# Altium schematic sheet AUAV_X05_IMU.SchDoc(AUAV_X05_IMU)
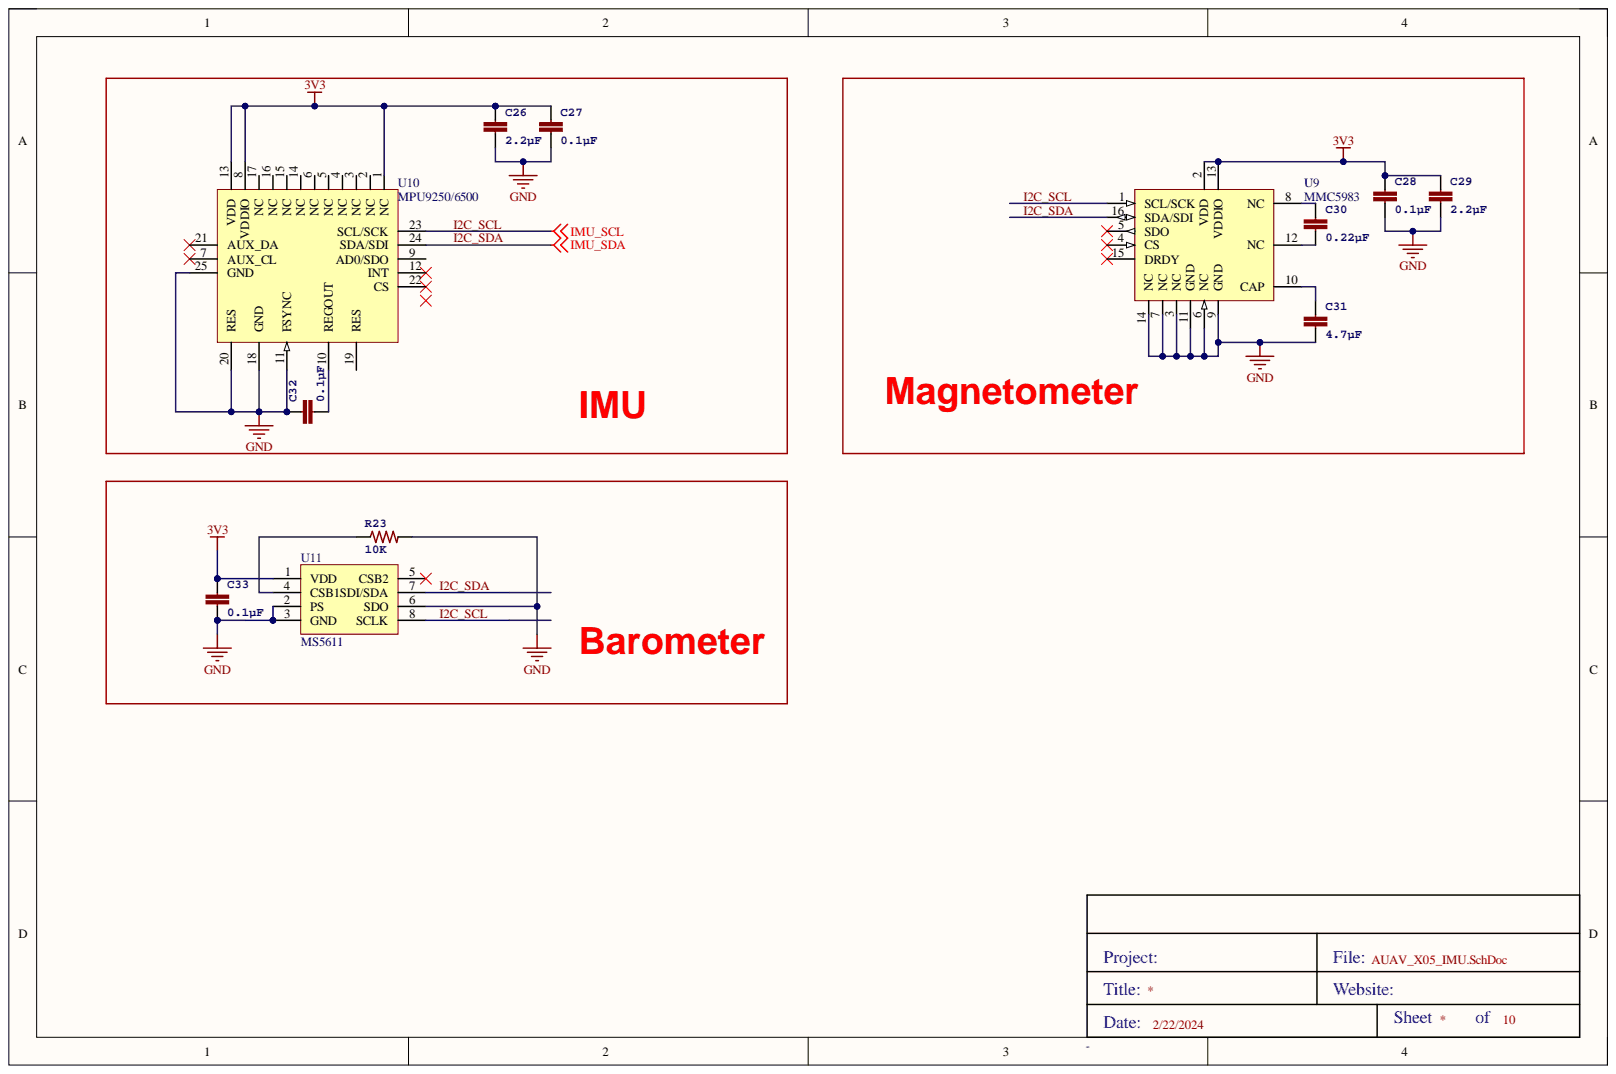
[diagram p0: AUAV_X05_IMU.SchDoc]

## Parts
*?  Title Block  (value not drawn)
C26  2.2µF  [0402-CAP]
C27  0.1µF  [0402-CAP]
C28  0.1µF  [0402-CAP]
C29  2.2µF  [0402-CAP]
C30  0.22µF  [0402-CAP]
C31  4.7µF  [0603-CAP]
C32  0.1µF  [0402-CAP]
C33  0.1µF  [0402-CAP]
R23  10K  [0402-RES]
U9  MMC5983  [MMC5983]
U10  MPU9250/6500  [QFN24_3X3X1_IVS]
U11  MS5611  [MS5611-01BA]

## Nets
3V3: C26.P$1 C27.P$1 C28.P$1 C29.P$1 C33.P$1 U9.2(VDD) U9.13(VDDIO) U10.1(NC) U10.8(VDDIO) U10.13(VDD) U11.1(VDD)
GND: C26.P$2 C27.P$2 C28.P$2 C29.P$2 C31.P$2 C32.P$1 C33.P$2 R23.P$2 U9.3(NC) U9.6(NC) U9.7(NC) U9.9(GND) U9.11(GND) U9.14(NC) U10.11(FSYNC) U10.18(GND) U10.20(RES) U10.25(GND) U11.2(PS) U11.3(GND) U11.6(SDO)
IMU_SCL: U9.1(SCL/SCK) U10.23(SCL/SCK) U11.8(SCLK)
IMU_SDA: U9.16(SDA/SDI) U10.24(SDA/SDI) U11.7(SDI/SDA)
NetC30_P$1: C30.P$1 U9.8(NC)
NetC30_P$2: C30.P$2 U9.12(NC)
NetC31_P$1: C31.P$1 U9.10(CAP)
NetC32_P$2: C32.P$2 U10.10(REGOUT)
NetR23_P$1: R23.P$1 U11.4(CSB1)

Not connected: U9 4(CS) 5(SDO) 15(DRDY); U10 2(NC) 3(NC) 4(NC) 5(NC) 6(NC) 7(AUX_CL) 9(AD0/SDO) 12(INT) 14(NC) 15(NC) 16(NC) 17(NC) 19(RES) 21(AUX_DA) 22(CS); U11 5(CSB2)

## Library data of the parts
C26-C30 C32 C33 = CAP0402: CAP CER 8PF 50V C0G 0402; 3DR_PARTNO: ECM0710; PARTNO: C1005C0G1H080C; Value: 8p; Component Kind: Standard; Supplier 1: Digi-Key; Supplier Part Number 1: 445-4890-1-ND; Library Name: AUAV_X05.SchLib
C31 = CAP0603: CAP CER 2.2UF 16V 10% X6S 0603; 3DR_PARTNO: ECM0619; PARTNO: C1608X6S1C225K080AC; Value: 2u2; Component Kind: Standard; Supplier 1: Digi-Key; Supplier Part Number 1: 445-7438-1-ND; Library Name: AUAV_X05.SchLib
R23 = RESISTOR0402-RES: RES 10K OHM 1/16W 1% 0402 SMD; 3DR_PARTNO: ECM0800; PARTNO: RC0402FR-0710KL; Component Kind: Standard; Supplier 1: Digi-Key; Supplier Part Number 1: 311-10.0KLRCT-ND; Value: 10K; Library Name: AUAV_X05.SchLib
U10 = MPU6500: Component Kind: Standard; Library Name: AUAV_X05.SchLib
U11 = MS5611-01BA03: Component Kind: Standard; Library Name: AUAV_X05.SchLib
*? = Title Block: Component Kind: Standard; Size: -; Library Name: AUAV_X05.SchLib
U9 = MMC5983: Component Kind: Standard; Library Name: AUAV_X05.SchLib
